# Altium schematic sheet Sheet2.SchDoc(Sheet2)
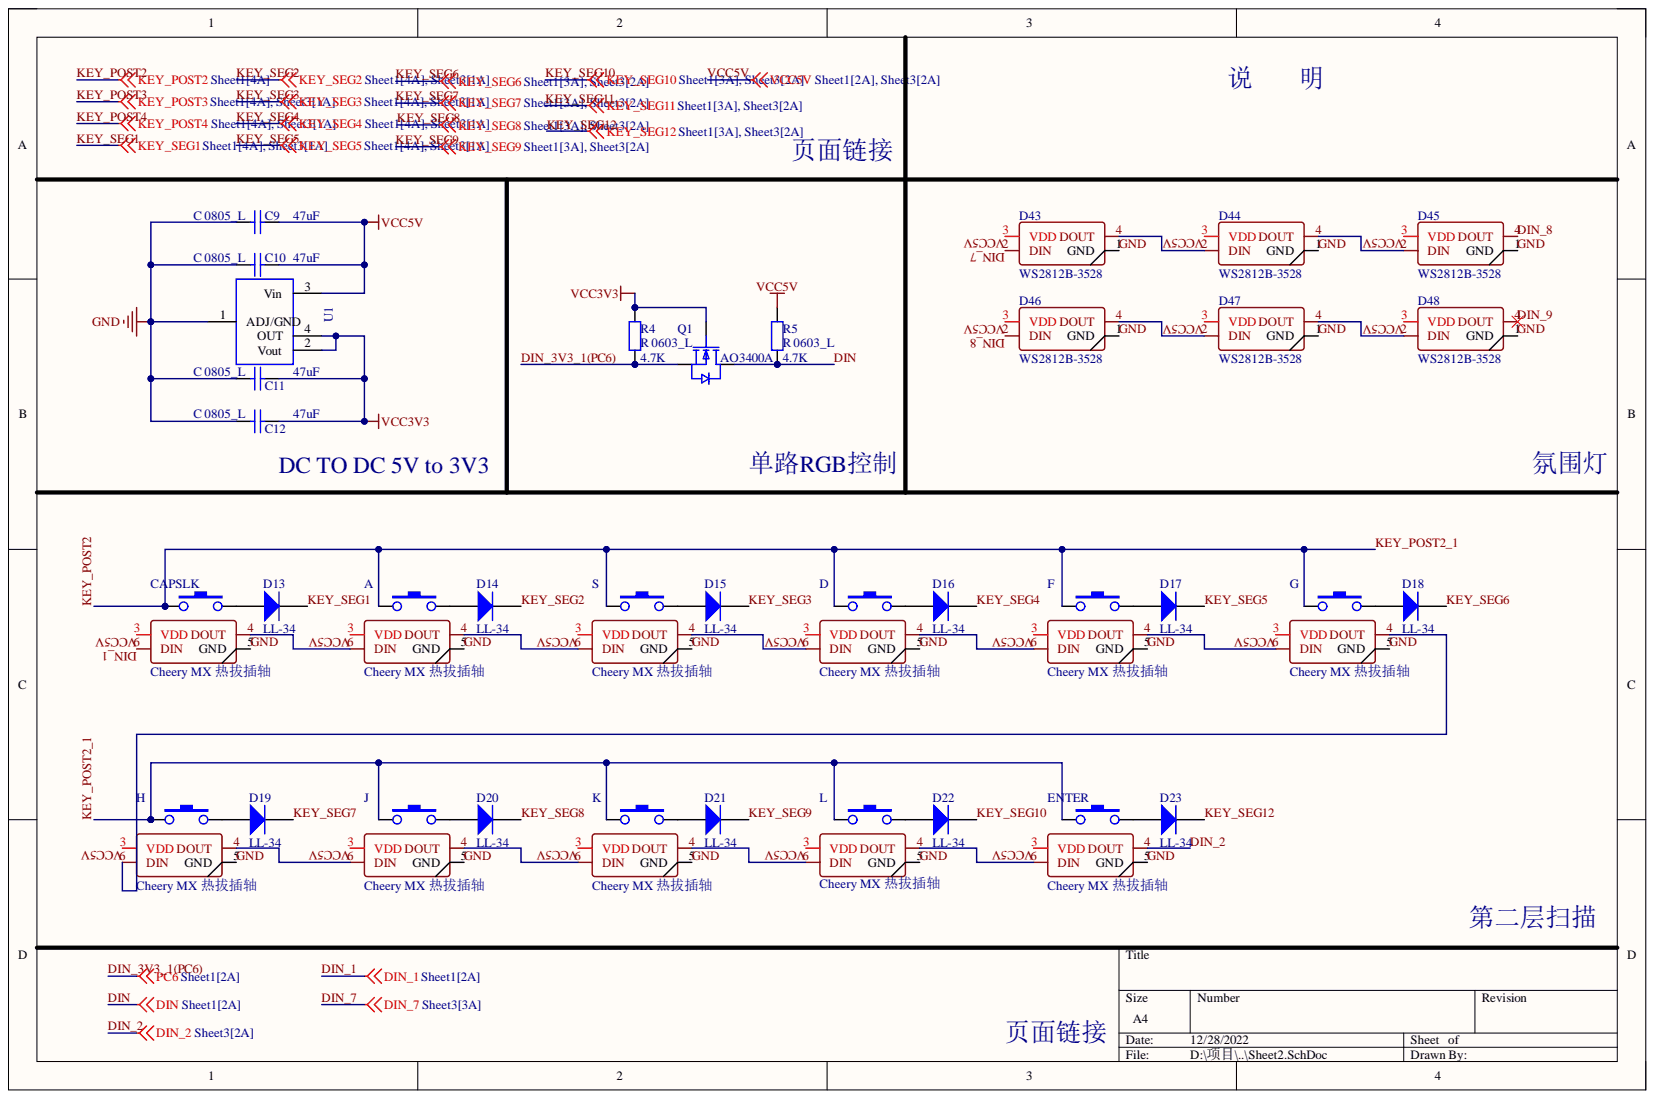
[diagram p0: Sheet2.SchDoc]

## Parts
A  Cheery MX 热拔插轴  [MX_SW-HOTPLUG]
C9  C 0805_L  value 47uF  [C 0805_L]
C10  C 0805_L  value 47uF  [C 0805_L]
C11  C 0805_L  value 47uF  [C 0805_L]
C12  C 0805_L  value 47uF  [C 0805_L]
CAPSLK  Cheery MX 热拔插轴  [MX_SW-HOTPLUG]
D  Cheery MX 热拔插轴  [MX_SW-HOTPLUG]
D13  LL-34  [LL-34_L3.7-W1.6-RD]
D14  LL-34  [LL-34_L3.7-W1.6-RD]
D15  LL-34  [LL-34_L3.7-W1.6-RD]
D16  LL-34  [LL-34_L3.7-W1.6-RD]
D17  LL-34  [LL-34_L3.7-W1.6-RD]
D18  LL-34  [LL-34_L3.7-W1.6-RD]
D19  LL-34  [LL-34_L3.7-W1.6-RD]
D20  LL-34  [LL-34_L3.7-W1.6-RD]
D21  LL-34  [LL-34_L3.7-W1.6-RD]
D22  LL-34  [LL-34_L3.7-W1.6-RD]
D23  LL-34  [LL-34_L3.7-W1.6-RD]
D43  WS2812B-3528  [WS2812B-3528，反贴RGBLED灯]
D44  WS2812B-3528  [WS2812B-3528，反贴RGBLED灯]
D45  WS2812B-3528  [WS2812B-3528，反贴RGBLED灯]
D46  WS2812B-3528  [WS2812B-3528，反贴RGBLED灯]
D47  WS2812B-3528  [WS2812B-3528，反贴RGBLED灯]
D48  WS2812B-3528  [WS2812B-3528，反贴RGBLED灯]
ENTER  Cheery MX 热拔插轴  [MX_SW-HOTPLUG]
F  Cheery MX 热拔插轴  [MX_SW-HOTPLUG]
G  Cheery MX 热拔插轴  [MX_SW-HOTPLUG]
H  Cheery MX 热拔插轴  [MX_SW-HOTPLUG]
J  Cheery MX 热拔插轴  [MX_SW-HOTPLUG]
K  Cheery MX 热拔插轴  [MX_SW-HOTPLUG]
L  Cheery MX 热拔插轴  [MX_SW-HOTPLUG]
Q1  AO3400A  [AO3400A]
R4  R 0603_L  value 4.7K  [R 0603_L]
R5  R 0603_L  value 4.7K  [R 0603_L]
S  Cheery MX 热拔插轴  [MX_SW-HOTPLUG]
U1  SPX1117M3-L-3-3  [SPX1117M3-L-3-3/TR]  (value not drawn)

## Nets
VCC3V3: C11.2 C12.2 Q1.1 R4.2 U1.2(Vout) U1.4(OUT)
DIN: Q1.3 R5.1
DIN_1: CAPSLK.6(DIN)
DIN_2: ENTER.4(DOUT)
DIN_3V3_1(PC6): Q1.2 R4.1
DIN_7: D43.2(DIN)
DIN_8: D45.4(DOUT) D46.2(DIN)
DIN_9: D48.4(DOUT)
GND: A.5(GND) C9.1 C10.1 C11.1 C12.1 CAPSLK.5(GND) D.5(GND) D43.1(GND) D44.1(GND) D45.1(GND) D46.1(GND) D47.1(GND) D48.1(GND) ENTER.5(GND) F.5(GND) G.5(GND) H.5(GND) J.5(GND) K.5(GND) L.5(GND) S.5(GND) U1.1(ADJ/GND)
KEY_POST2: A.1 CAPSLK.1 D.1 ENTER.1 F.1 G.1 H.1 J.1 K.1 L.1 S.1
KEY_SEG1: D13.2
KEY_SEG2: D14.2
KEY_SEG3: D15.2
KEY_SEG4: D16.2
KEY_SEG5: D17.2
KEY_SEG6: D18.2
KEY_SEG7: D19.2
KEY_SEG8: D20.2
KEY_SEG9: D21.2
KEY_SEG10: D22.2
KEY_SEG12: D23.2
VCC5V: A.3(VDD) C9.2 C10.2 CAPSLK.3(VDD) D.3(VDD) D43.3(VDD) D44.3(VDD) D45.3(VDD) D46.3(VDD) D47.3(VDD) D48.3(VDD) ENTER.3(VDD) F.3(VDD) G.3(VDD) H.3(VDD) J.3(VDD) K.3(VDD) L.3(VDD) R5.2 S.3(VDD) U1.3(Vin)
NetA_2: A.2 D14.1
NetA_4: A.4(DOUT) S.6(DIN)
NetA_6: A.6(DIN) CAPSLK.4(DOUT)
NetCAPSLK_2: CAPSLK.2 D13.1
NetD15_1: D15.1 S.2
NetD16_1: D.2 D16.1
NetD17_1: D17.1 F.2
NetD18_1: D18.1 G.2
NetD19_1: D19.1 H.2
NetD20_1: D20.1 J.2
NetD21_1: D21.1 K.2
NetD22_1: D22.1 L.2
NetD23_1: D23.1 ENTER.2
NetD43_4: D43.4(DOUT) D44.2(DIN)
NetD44_4: D44.4(DOUT) D45.2(DIN)
NetD46_4: D46.4(DOUT) D47.2(DIN)
NetD47_4: D47.4(DOUT) D48.2(DIN)
NetD_4: D.4(DOUT) F.6(DIN)
NetD_6: D.6(DIN) S.4(DOUT)
NetENTER_6: ENTER.6(DIN) L.4(DOUT)
NetF_4: F.4(DOUT) G.6(DIN)
NetG_4: G.4(DOUT) H.6(DIN)
NetH_4: H.4(DOUT) J.6(DIN)
NetJ_4: J.4(DOUT) K.6(DIN)
NetK_4: K.4(DOUT) L.6(DIN)
KEY_POST3:
KEY_POST4:
KEY_SEG11:

## Library data of the parts
A CAPSLK D ENTER F G H J K L S = Cheery MX 热拔插轴: 机械键盘轴（Cheery MX）; Component Kind: Standard; Library Name: BaseLib.SchLib
C9 C10 C12 = C 0805_L: Capacitor; Component Kind: Standard; LatestRevisionDate: 17-Jul-2002; LatestRevisionNote: Re-released for DXP Platform.; Library Name: Schlib1.SchLib; PackageDescription: Radial Cap, Thru-Hole; 2 Leads; 0.3 in Pin Spacing; PackageReference: RAD-0.3; Published: 8-Jun-2000; Publisher: Altium Limited
C11 = C 0805_L: Capacitor; Value: 47uF; Component Kind: Standard; LatestRevisionDate: 17-Jul-2002; LatestRevisionNote: Re-released for DXP Platform.; Library Name: Schlib1.SchLib; PackageDescription: Radial Cap, Thru-Hole; 2 Leads; 0.3 in Pin Spacing; PackageReference: RAD-0.3; Published: 8-Jun-2000; Publisher: Altium Limited
D13-D23 = LL4148: 开关二极管（Switching diode）; Code_JEDEC: DO-214-AB; Component Kind: Standard; LatestRevisionDate: 17-Jul-2002; LatestRevisionNote: Re-released for DXP Platform.; Library Name: BaseLib.SchLib; PackageDescription: DO-214-AB/SMC; 2 C-Bend Leads; Body 7.9 x 5.9 mm, inc. leads (LxW); PackageReference: SMC; PackageVersion: Sep-1996; Published: 8-Jun-2000; Publisher: Altium Limited
D43-D48 = WS2812B-3528: WS2812B-3528,反贴LED，RGB灯; Component Kind: Standard; Library Name: BaseLib.SchLib
Q1 = 2N7002,215: Manufacturer: Nexperia(安世); Add into BOM: yes; Continuous Drain Current (Id): 300mA; Drain Source On Resistance (RDS(on)@Vgs,Id): 5Ω@10V,500mA; Drain Source Voltage (Vdss): 60V; Gate Threshold Voltage (Vgs(th)@Id): 2.5V@250A; Power Dissipation (Pd): 830mW; Type: N沟道; Component Kind: Standard; Convert to PCB: yes; Datasheet: https://atta.szlcsc.com/upload/public/pdf/source/20170103/1483439882638.pdf; Device: 2N7002,215; JLCPCB Part Class: Extended Part; LCSC Part Name: N沟道 60V 300mA; Library Name: Schlib1.SchLib; Manufacturer Part: 2N7002,215; Supplier: LCSC; Supplier Footprint: SOT-23(SOT-23-3); Supplier Part: C65189; Symbol: 2N7002,215
R4 R5 = R 0603_L: Resistor; Component Kind: Standard; LatestRevisionDate: 17-Jul-2002; LatestRevisionNote: Re-released for DXP Platform.; Library Name: Schlib1.SchLib; PackageDescription: Axial Device, Thru-Hole; 2 Leads; 0.4 in Pin Spacing; PackageReference: AXIAL-0.4; Published: 8-Jun-2000; Publisher: Altium Limited
U1 = SPX1117M3-L-3-3: Manufacturer: UTC(友顺); Add into BOM: yes; Device: LM317G-AA3-R; Dropout Voltage: 5V@(10mA); Maximum Input Voltage: 40V; Number of Outputs: 1; Output Current: 1A; Output Polarity: 正; Output Type: 可调; Output Voltage: 1.3V~37V; Component Kind: Standard; Convert to PCB: yes; Datasheet: https://atta.szlcsc.com/upload/public/pdf/source/20160416/1460799387250.pdf; JLCPCB Part Class: Extended Part; LCSC Part Name: Vin=40V Vout=1.3V~37V 1A; Library Name: Schlib1.SchLib; Manufacturer Part: LM317G-AA3-R; Supplier: LCSC; Supplier Footprint: SOT-223; Supplier Part: C19651; Symbol: LM317G-AA3-R
